# Altium schematic sheet Comm.SchDoc(Comm)
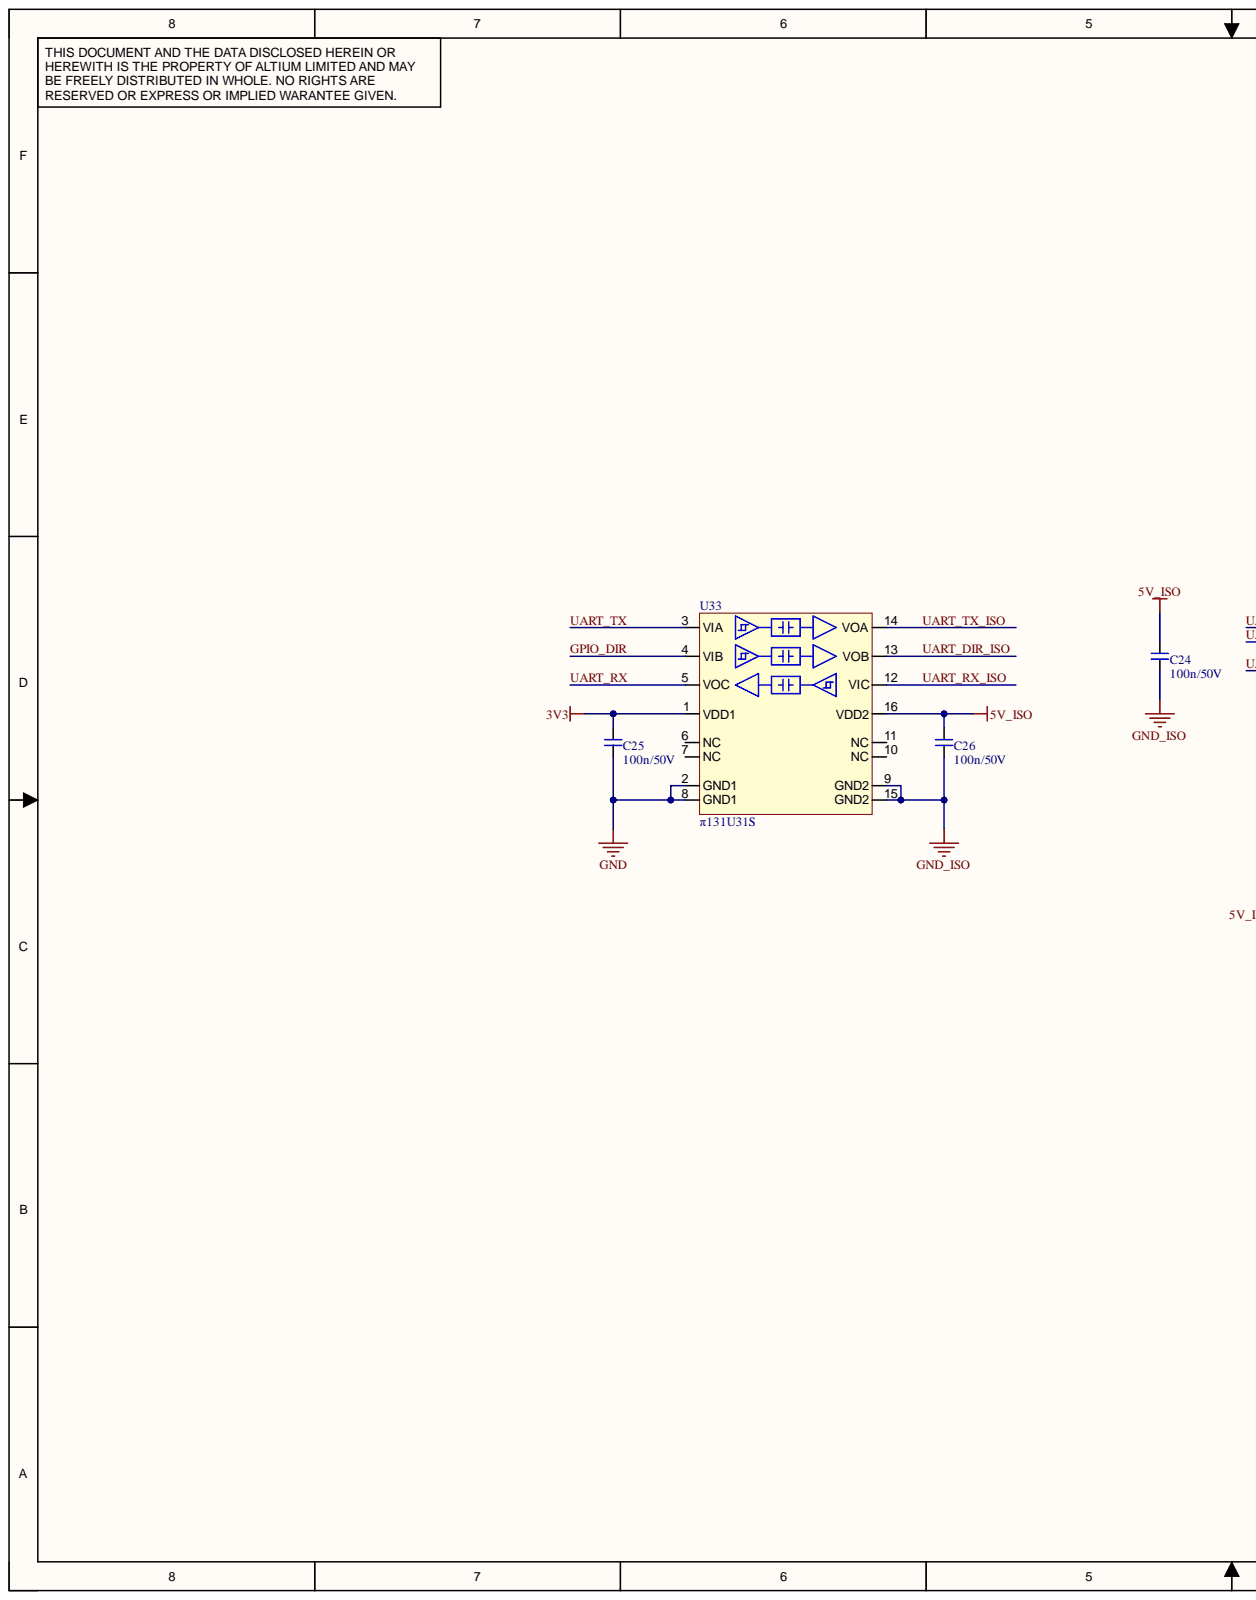
[diagram p0: Comm.SchDoc, left]
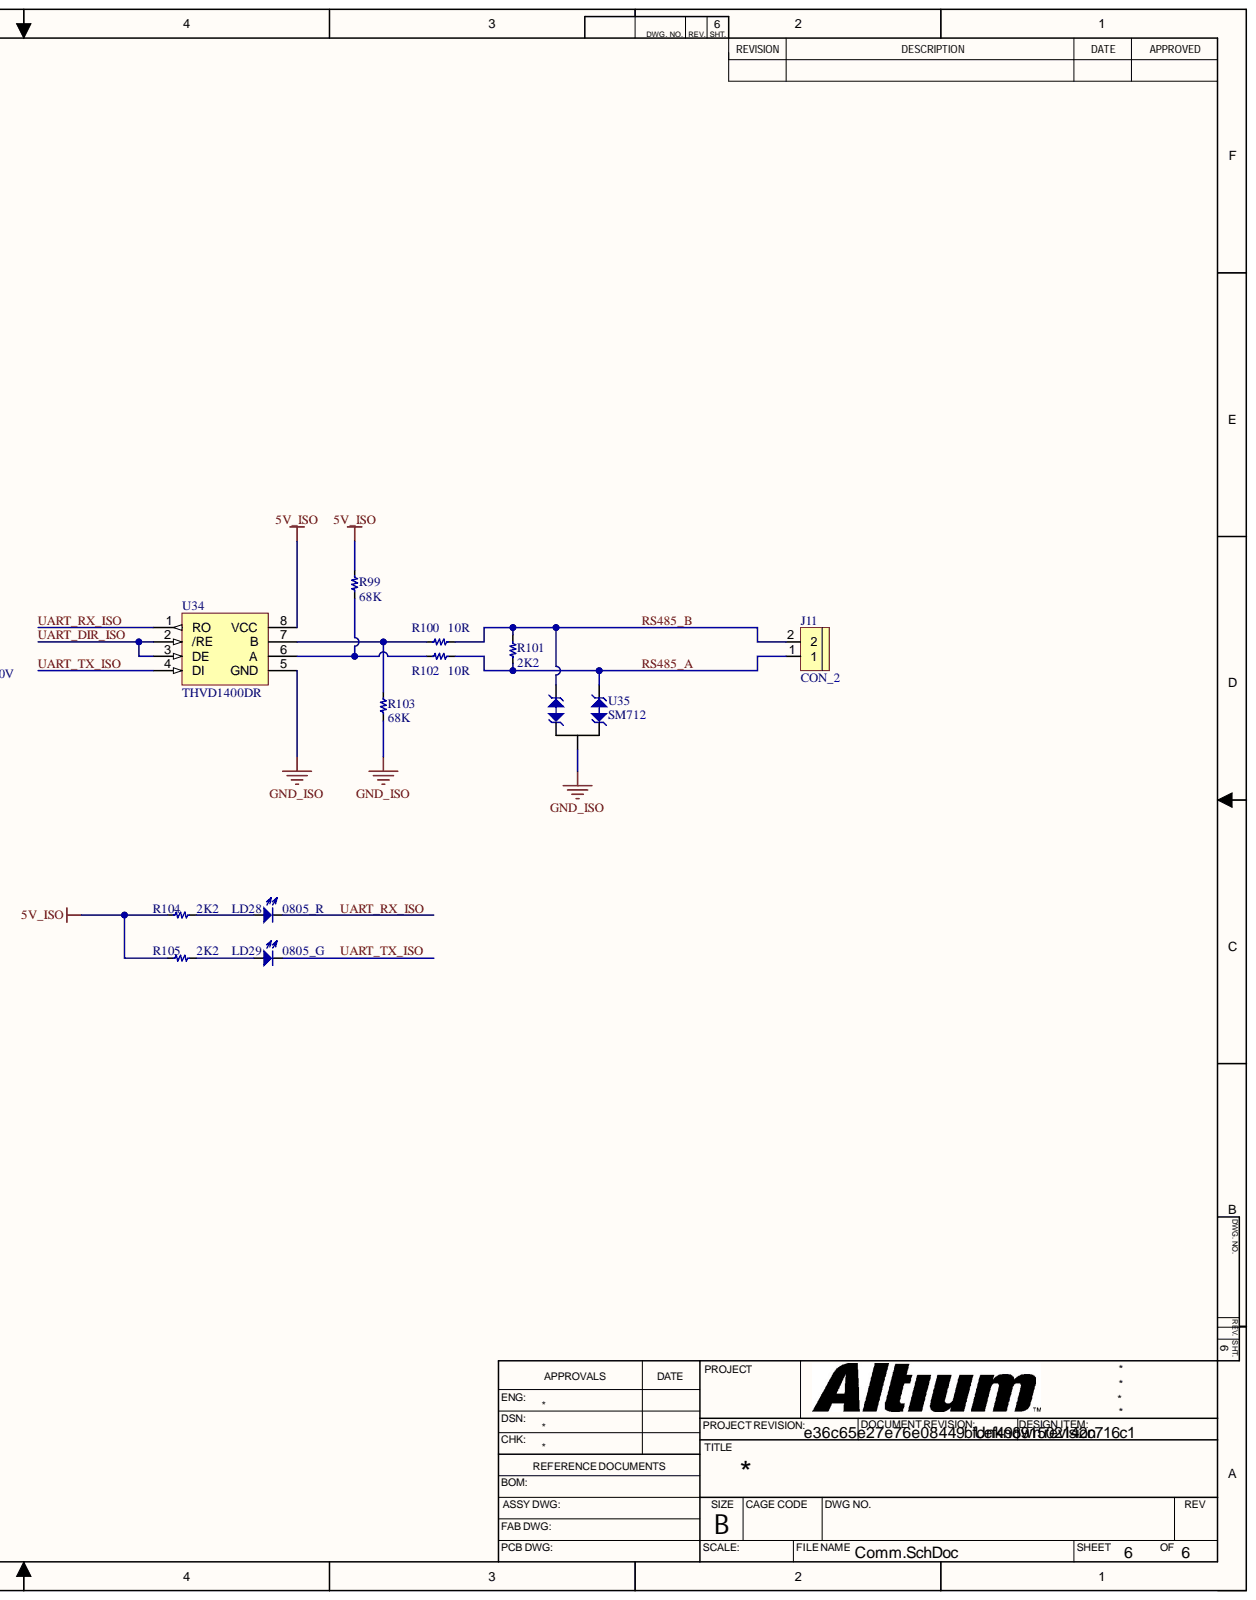
[diagram p1: Comm.SchDoc, right]

## Parts
C24  100n/50V  [C-0805]
C25  100n/50V  [C-0805]
C26  100n/50V  [C-0805]
J11  CON_2  [KF-5.0-2P]
LD28  0805_R  [LED_0805_R]
LD29  0805_G  [LED_0805_G]
R99  68K  [R-0805]
R100  10R  [R-0805]
R101  2K2  [R-0805]
R102  10R  [R-0805]
R103  68K  [R-0805]
R104  2K2  [R-0805]
R105  2K2  [R-0805]
U33  π131U31S  [SSOP-16]
U34  THVD1400DR  [SOIC-8]
U35  SM712  [SM712-SOT23]

## Nets
3V3: C25.2 U33.1(VDD1)
5V_ISO: C24.2 C26.2 R99.2 R104.2 R105.2 U33.16(VDD2) U34.8(VCC)
GND: C25.1 U33.2(GND1) U33.8(GND1)
GND_ISO: C24.1 C26.1 R103.1 U33.9(GND2) U33.15(GND2) U34.5(GND) U35.3
GPIO_DIR: U33.4(VIB)
RS485_A: J11.1 R101.2 R102.1 U35.2
RS485_B: J11.2 R100.1 R101.1 U35.1
UART_DIR_ISO: U33.13(VOB) U34.2(/RE) U34.3(DE)
UART_RX: U33.5(VOC)
UART_RX_ISO: LD28.2 U33.12(VIC) U34.1(RO)
UART_TX: U33.3(VIA)
UART_TX_ISO: LD29.2 U33.14(VOA) U34.4(DI)
NetLD28_1: LD28.1 R104.1
NetLD29_1: LD29.1 R105.1
NetR99_1: R99.1 R102.2 U34.6(A)
NetR100_2: R100.2 R103.2 U34.7(B)

Not connected: U33 6(NC) 7(NC) 10(NC) 11(NC)

## Library data of the parts
C24-C26 = CERAMIC_CAP: Component Kind: Standard; Library Name: NXVu.SchLib
LD28 = LED_0805_R: Component Kind: Standard; Library Name: NXVu.SchLib
LD29 = LED_0805_G: Component Kind: Standard; Library Name: NXVu.SchLib
R99-R105 = RESISTOR: Component Kind: Standard; Library Name: NXVu.SchLib
U33 = 131U30: 250kV/us SSOP-16 Digital Isolators RoHS; Component Kind: Standard; Library Name: NXVu.SchLib
U34 = MAX485: 4.5V~5.5V Transceiver 200Kbps 256 Half-Duplex SOIC-8 Drivers, Receivers, Transceivers RoHS; Component Kind: Standard; Library Name: NXVu.SchLib
U35 = SM712: 26VC 14VC Clamp ESD DIODE SOT-23; Component Kind: Standard; Library Name: NXVu.SchLib
J11 = CON_2: Component Kind: Standard; Library Name: NXVu.SchLib
